# Altium schematic sheet Sheet1.SchDoc
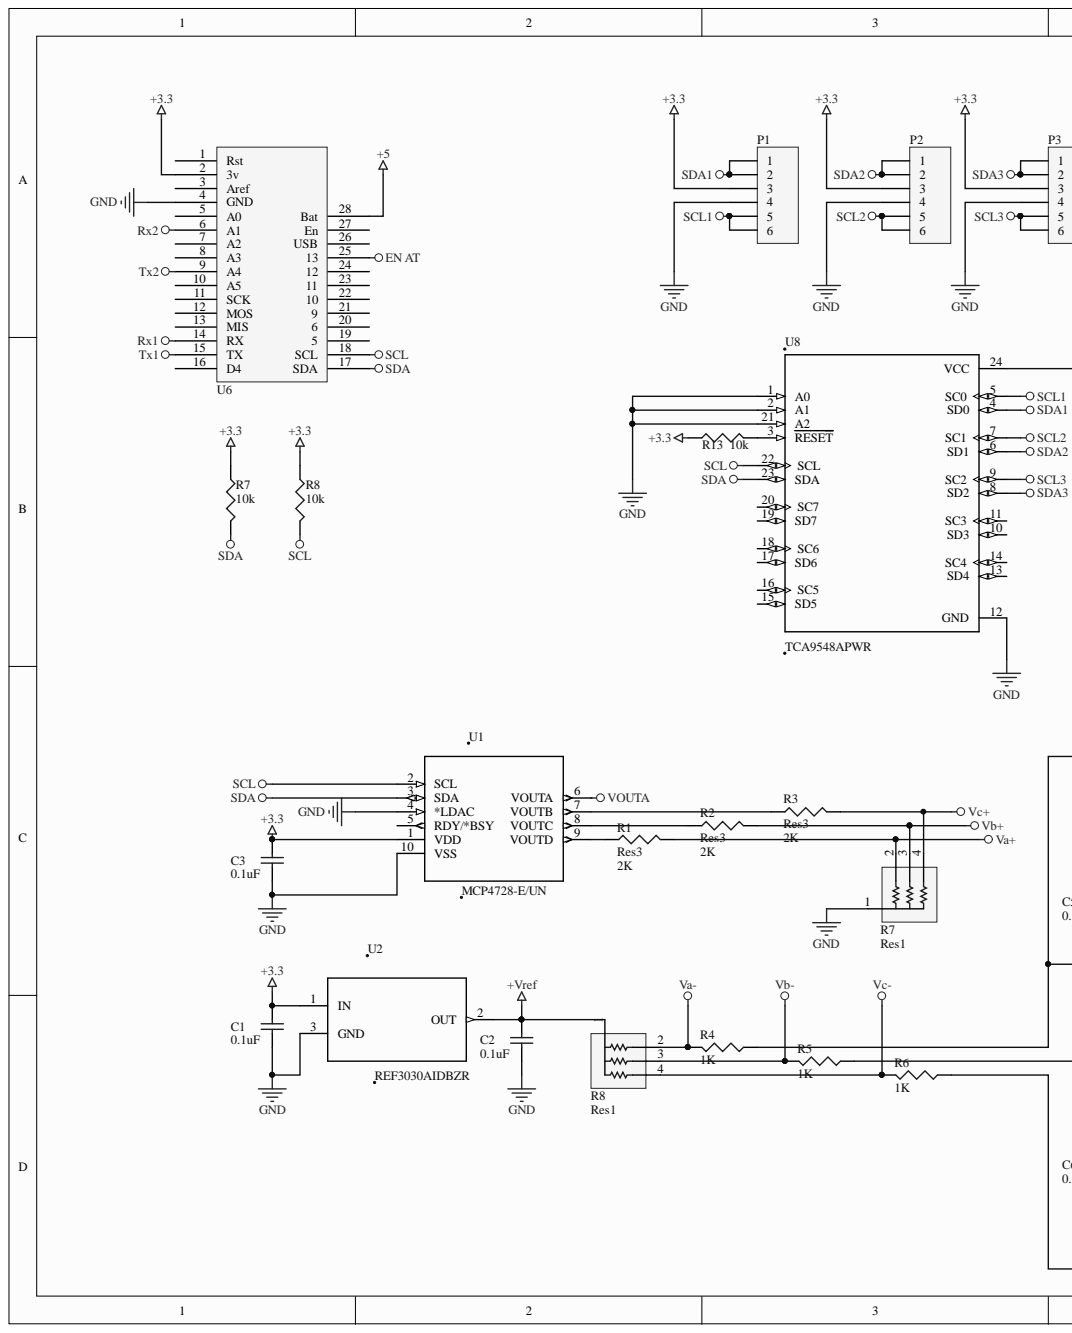
[diagram p0: Sheet1.SchDoc, left]
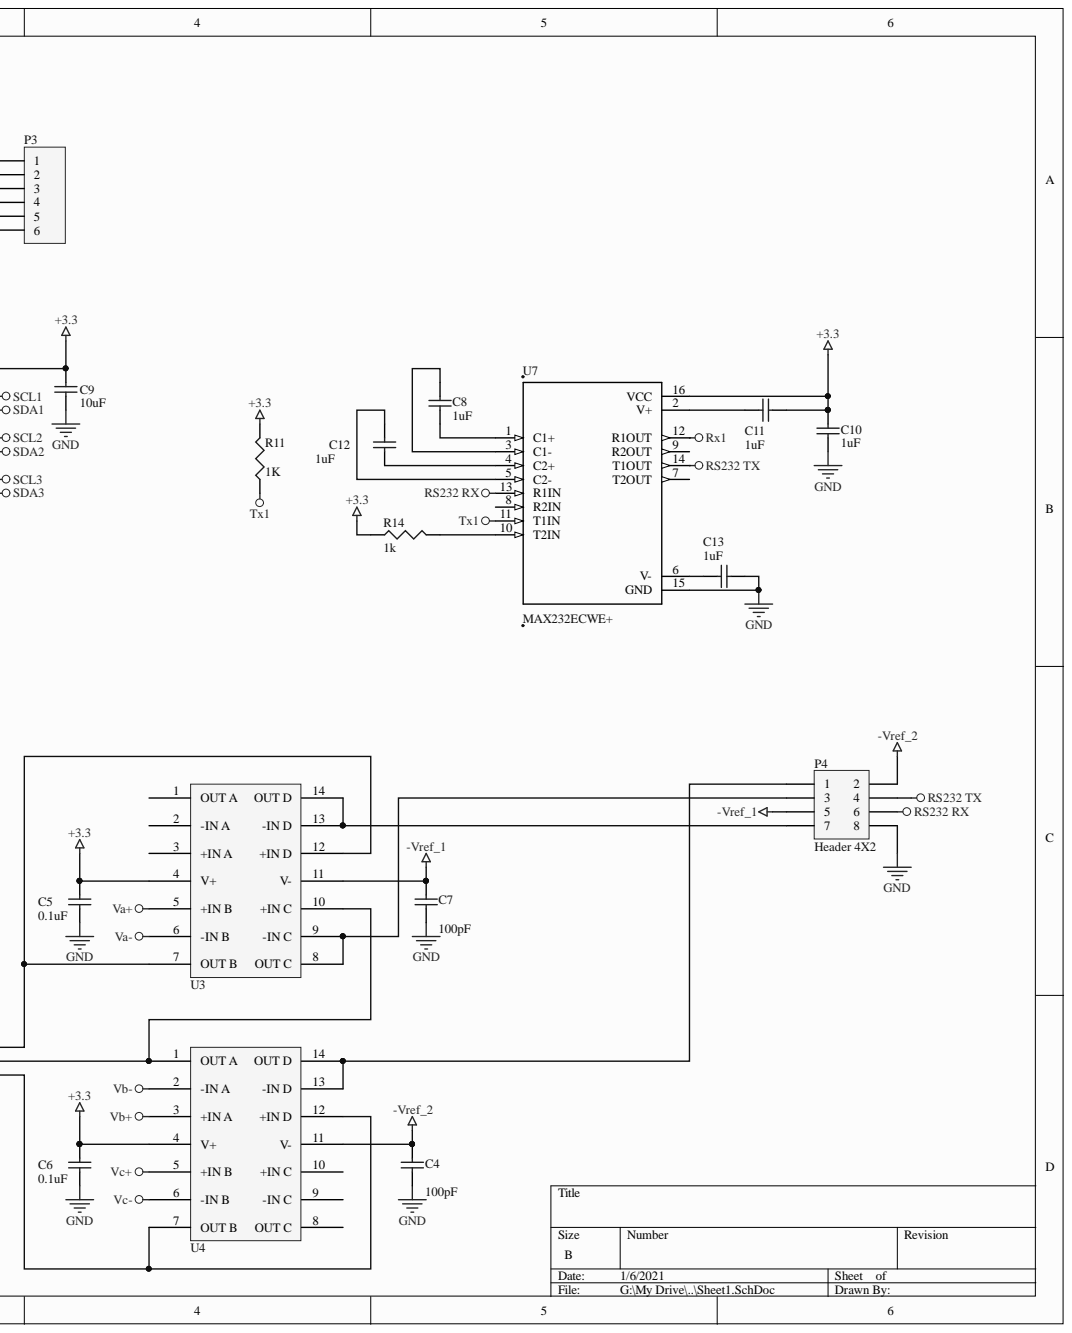
[diagram p1: Sheet1.SchDoc, right]

## Parts
C1  value 0.1uF  [1608[0603]]  (hidden comment: Cap Semi)
C2  value 0.1uF  [1608[0603]]  (hidden comment: Cap Semi)
C3  value 0.1uF  [1608[0603]]  (hidden comment: Cap Semi)
C4  value 100pF  [1608[0603]]  (hidden comment: Cap Semi)
C5  value 0.1uF  [1608[0603]]  (hidden comment: Cap Semi)
C6  value 0.1uF  [1608[0603]]  (hidden comment: Cap Semi)
C7  value 100pF  [1608[0603]]  (hidden comment: Cap Semi)
C8  value 1uF  [1608[0603]]  (hidden comment: Cap Semi)
C9  value 10uF  [1608[0603]]  (hidden comment: Cap Semi)
C10  value 1uF  [1608[0603]]  (hidden comment: Cap Semi)
C11  value 1uF  [1608[0603]]  (hidden comment: Cap Semi)
C12  value 1uF  [1608[0603]]  (hidden comment: Cap Semi)
C13  value 1uF  [1608[0603]]  (hidden comment: Cap Semi)
P1  Header 6  [flow sensor]  (value not drawn)
P2  Header 6  [flow sensor]  (value not drawn)
P3  Header 6  [flow sensor]  (value not drawn)
P4  Header 4X2  [Molex MicroFit 2x4]
R1  Res3  value 2K  [J1-0603]
R2  Res3  value 2K  [J1-0603]
R3  Res3  value 2K  [J1-0603]
R4  value 1K  [0402]  (hidden comment: Res3)
R5  value 1K  [0402]  (hidden comment: Res3)
R6  value 1K  [0402]  (hidden comment: Res3)
R7  value 10k  [0402]  (hidden comment: Res3)
R7  Res1  [resistor Bus]
R8  value 10k  [0402]  (hidden comment: Res3)
R8  Res1  [resistor Bus]
R11  value 1K  [J1-0603]  (hidden comment: Res3)
R13  value 10k  [0402]  (hidden comment: Res3)
R14  value 1k  [J1-0603]  (hidden comment: Res3)
U1  MCP4728-E/UN  [*SOP50P490X110-10N]
U2  REF3030AIDBZR  [*SOT95P237X112-3N]
U3  TLV6004  [*SOP65P640X120-14N]  (value not drawn)
U4  TLV6004  [*SOP65P640X120-14N]  (value not drawn)
U6  Feather M4  [*ADAFRUIT_2829_2829(Primary)]  (value not drawn)
U7  MAX232ECWE+  [*SOIC127P1032X265-16N]
U8  TCA9548APWR  [*SOP65P640X120-24N]

## Nets
+3.3: C1.2 C3.2 C5.2 C6.2 C9.1 C10.1 C11.1 P1.3 P2.3 P3.3 R7.1 R8.1 R11.2 R13.1 R14.1 U1.1(VDD) U2.1 U3.4(V+) U4.4(V+) U6.2(3v) U7.16(VCC) U8.24(VCC)
+5: U6.28(Bat)
+VREF: C2.2 R8.1 U2.2
-VREF_1: C7.2 P4.5 U3.11(V-)
-VREF_2: C4.2 P4.2 U4.11(V-)
EN AT: U6.25
GND: C1.1 C2.1 C3.1 C4.1 C5.1 C6.1 C7.1 C9.2 C10.2 C13.1 P1.4 P2.4 P3.4 P4.8 R7.1 U1.4(*LDAC) U1.10(VSS) U2.3 U6.4(GND) U7.15(GND) U8.2(A1) U8.12(GND) U8.21(A2)
RS232 RX: P4.6 U7.13(R1IN)
RS232 TX: P4.4 U7.14(T1OUT)
RX1: U6.14(RX) U7.12(R1OUT)
RX2: U6.6(A1)
SCL: R8.2 U1.2(SCL) U6.18(SCL) U8.22(SCL)
SCL1: P1.5 P1.6 U8.5(SC0)
SCL2: P2.5 P2.6 U8.7(SC1)
SCL3: P3.5 P3.6 U8.9(SC2)
SDA: R7.2 U1.3(SDA) U6.17(SDA) U8.23(SDA)
SDA1: P1.1 P1.2 U8.4(SD0)
SDA2: P2.1 P2.2 U8.6(SD1)
SDA3: P3.1 P3.2 U8.8(SD2)
TX1: R11.1 U6.15(TX) U7.11(T1IN)
TX2: U6.9(A4)
VA+: R1.2 R7.2 U3.5(+IN_B)
VA-: R4.2 R8.2 U3.6(-IN_B)
VB+: R2.2 R7.3 U4.3(+IN_A)
VB-: R5.2 R8.3 U4.2(-IN_A)
VC+: R3.2 R7.4 U4.5(+IN_B)
VC-: R6.2 R8.4 U4.6(-IN_B)
VOUTA: U1.6(VOUTA)
NetC8_1: C8.1 U7.3(C1-)
NetC8_2: C8.2 U7.1(C1+)
NetC11_2: C11.2 U7.2(V+)
NetC12_1: C12.1 U7.5(C2-)
NetC12_2: C12.2 U7.4(C2+)
NetC13_2: C13.2 U7.6(V-)
NetP4_1: P4.1 U4.13(-IN_D) U4.14(OUT_D)
NetP4_3: P4.3 U3.8(OUT_C) U3.9(-IN_C)
NetP4_7: P4.7 U3.13(-IN_D) U3.14(OUT_D)
NetR1_1: R1.1 U1.9(VOUTD)
NetR2_1: R2.1 U1.8(VOUTC)
NetR3_1: R3.1 U1.7(VOUTB)
NetR4_1: R4.1 U3.7(OUT_B) U3.12(+IN_D)
NetR5_1: R5.1 U3.10(+IN_C) U4.1(OUT_A)
NetR6_1: R6.1 U4.7(OUT_B) U4.12(+IN_D)
NetR13_2: R13.2 U8.3(RESET)
NetR14_2: R14.2 U7.10(T2IN)

Not connected: U1 5(RDY/*BSY); U3 1(OUT_A) 2(-IN_A) 3(+IN_A); U4 8(OUT_C) 9(-IN_C) 10(+IN_C); U6 1(Rst) 3(Aref) 5(A0) 7(A2) 8(A3) 10(A5) 11(SCK) 12(MOS) 13(MIS) 16(D4) 19 20 21 22 23 24 26(USB) 27(En); U7 7(T2OUT) 8(R2IN) 9(R2OUT); U8 1(A0) 10(SD3) 11(SC3) 13(SD4) 14(SC4) 15(SD5) 16(SC5) 17(SD6) 18(SC6) 19(SD7) 20(SC7)

## Library data of the parts
C1-C13 = Cap Semi: Capacitor (Semiconductor SIM Model); Component Kind: Standard; LatestRevisionDate: 29-May-2009; LatestRevisionNote: IPC-7351 Footprint Added.; Library Name: Miscellaneous Devices.IntLib; PackageDescription: Chip Capacitor; PackageReference: C1206; Published: 8-Jun-2000; Simulation: CAP; Publisher: Altium Limited
P1-P3 = Header 6: Header, 6-Pin; Component Kind: Standard; LatestRevisionDate: 17-Jul-2002; LatestRevisionNote: Re-released for DXP Platform.; Library Name: Miscellaneous Connectors.IntLib; Publisher: Altium Limited
P4 = Header 4X2: Header, 4-Pin, Dual row; Component Kind: Standard; LatestRevisionDate: 17-Jul-2002; LatestRevisionNote: Re-released for DXP Platform.; Library Name: Miscellaneous Connectors.IntLib; Publisher: Altium Limited
R1-R8 R11 R13 R14 = Res3: Resistor; Component Kind: Standard; LatestRevisionDate: 29-May-2009; LatestRevisionNote: IPC-7351 Footprint Added.; Library Name: Miscellaneous Devices.IntLib; PackageDescription: Chip Resistor; PackageReference: J1-0603; Published: 8-Jun-2000; Simulation: RESISTOR; Publisher: Altium Limited
R7 R8 U3 U4 = Component_1: Component Kind: Standard
  R7 R8: Library Name: Schlib2.SchLib
  U3 U4: Library Name: Schlib1.SchLib
U1 = MCP4728-E/UN: MCP4728 Series 4 Ch I2C EEPROM Memory 5.5 V 12-Bit D/A Converter SMT - MSOP-10; MF: Microchip; Component Kind: Standard; Digi-Key_Part_Number: MCP4728-E/UN-ND; Digi-Key_Purchase_URL: https://www.digikey.com/product-detail/en/microchip-technology/MCP4728-E-UN/MCP4728-E-UN-ND/2125526?utm_source=snapeda&utm_medium=aggregator&utm_campaign=symbol; Library Name: MCP4728-E_UN.SchLib; MP: MCP4728-E/UN; Package: MSOP-10 Microchip; SnapEDA_Link: https://www.snapeda.com/parts/MCP4728-E/UN/Microchip/view-part/?ref=snap
U2 = REF3030AIDBZR: 3.0V 50ppm/Degrees C, 50uA in SOT23-3 Series _Bandgap_ Voltage Reference 3-SOT-23 -40 to 125; MF: Texas Instruments; Availability: Unavailable; Component Kind: Standard; Library Name: REF3030AIDBZR.SchLib; MP: REF3030AIDBZR; Package: SOT-23-3 Texas Instruments; Price: None; SnapEDA_Link: https://www.snapeda.com/parts/REF3030AIDBZR/Texas%20Instruments/view-part/?ref=snap
U7 = MAX232ECWE+: Dual Transmitter/Receiver RS-232 16-Pin SOIC W; MF: Maxim Integrated; Availability: Unavailable; Component Kind: Standard; Library Name: MAX232ECWE_.SchLib; MP: MAX232ECWE+; Package: SOIC-16 Maxim; Price: None; SnapEDA_Link: https://www.snapeda.com/parts/MAX232ECWE%2B/Maxim%20Integrated/view-part/?ref=snap
U8 = TCA9548APWR: Low-Voltage 8-Channel I2C Switch With Reset 24-TSSOP -40 to 85; MF: Texas Instruments; Availability: Unavailable; Component Kind: Standard; Library Name: TCA9548APWR.SchLib; MP: TCA9548APWR; Package: TSSOP-24 Texas Instruments; Price: None; SnapEDA_Link: https://www.snapeda.com/parts/TCA9548APWR/Texas%20Instruments/view-part/?ref=snap
U6 = Feather M4: Component Kind: Standard; Library Name: Schlib1.SchLib
